# Altium schematic sheet Sheet6.SchDoc
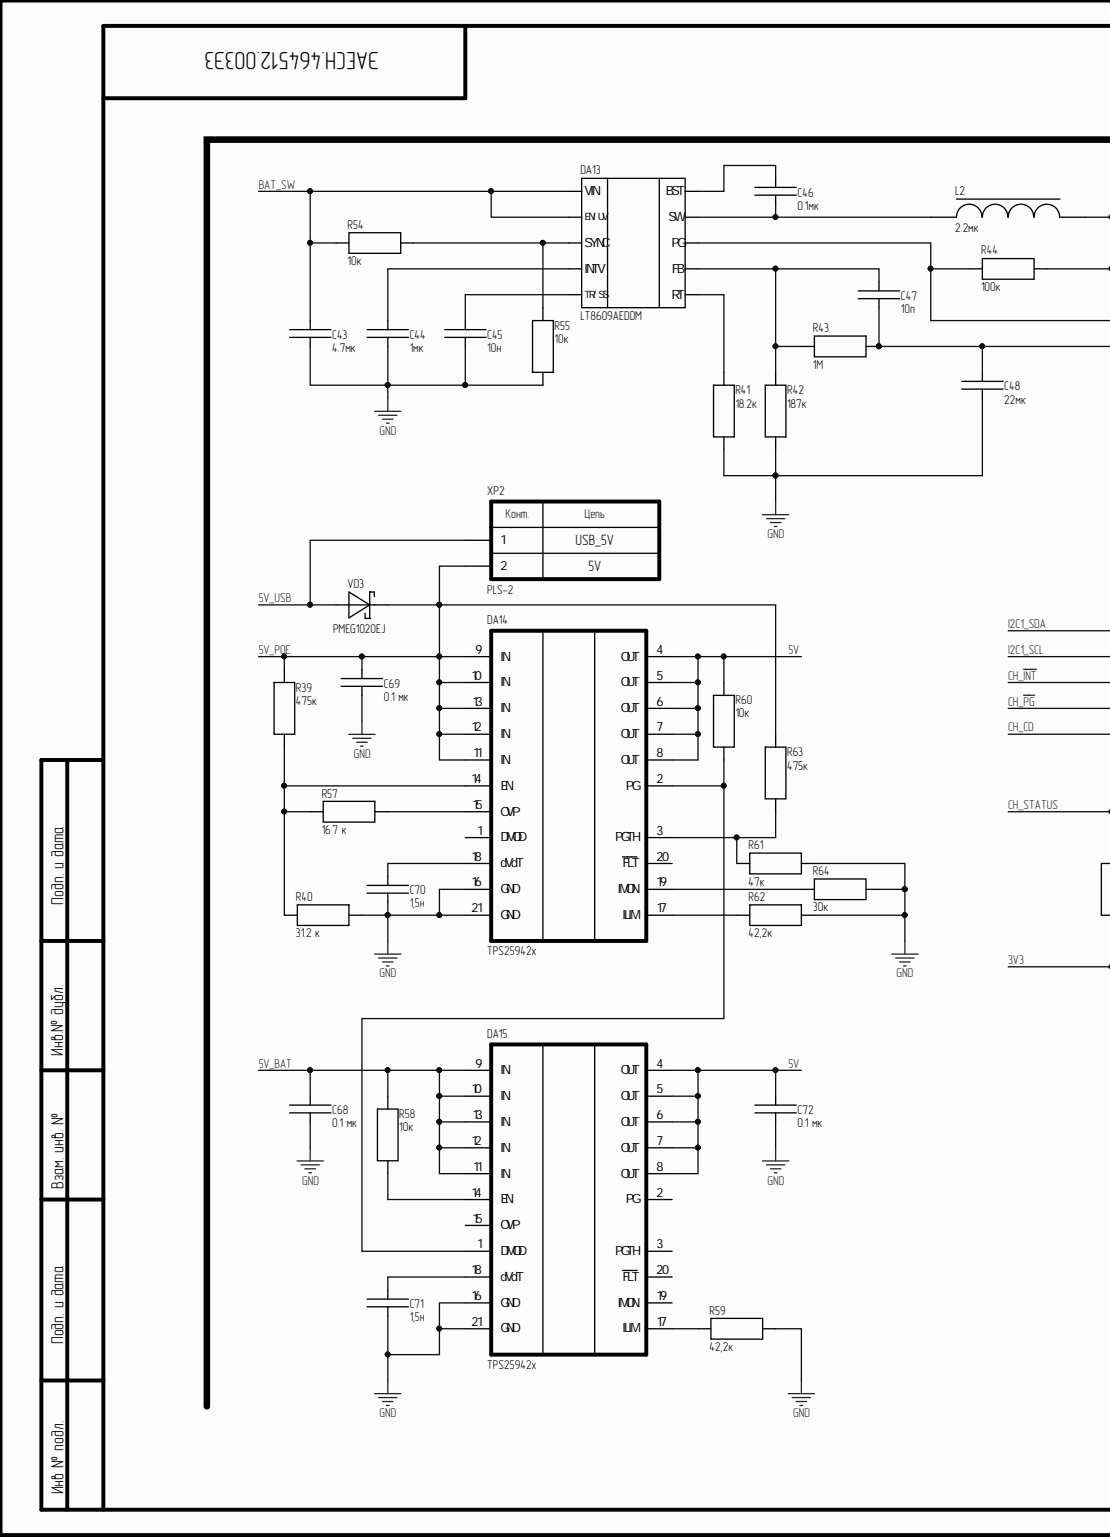
[diagram p0: Sheet6.SchDoc, left]
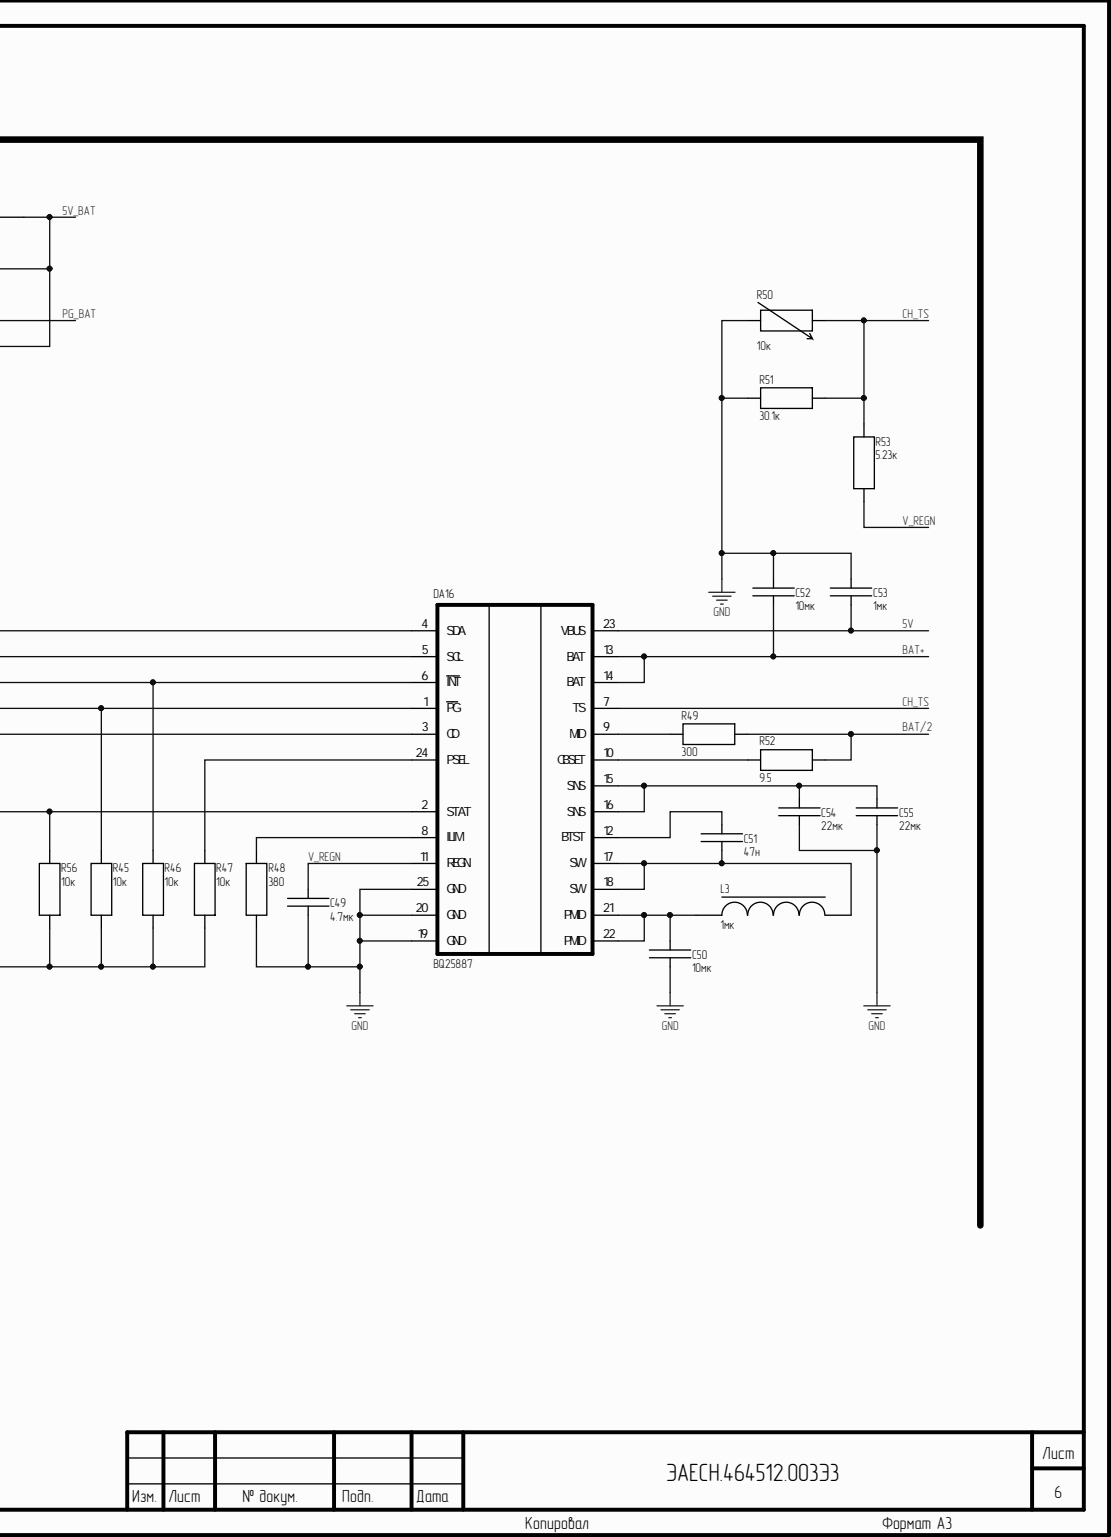
[diagram p1: Sheet6.SchDoc, right]

## Parts
C43  C  value 4.7мк  [С0603]
C44  C  value 1мк  [С0603]
C45  C  value 10н  [С0603]
C46  C  value 0.1мк  [С0603]
C47  C  value 10п  [С0603]
C48  C  value 22мк  [C1206]
C49  C  value 4.7мк  [С0603]
C50  C  value 10мк  [С0603]
C51  C  value 47н  [С0603]
C52  C  value 10мк  [С0603]
C53  C  value 1мк  [С0603]
C54  C  value 22мк  [C1206]
C55  C  value 22мк  [C1206]
C68  C  value 0.1 мк  [С0603]
C69  C  value 0.1 мк  [С0603]
C70  C  value 1,5н  [С0603]
C71  C  value 1,5н  [С0603]
C72  C  value 0.1 мк  [С0603]
DA13  LT8609AEDDM  [SON-10]
DA14  TPS25942x  [WQFN-20]
DA15  TPS25942x  [WQFN-20]
DA16  BQ25887  [VQFN-24]
L2  2.2мк  [IND_6.5x6.5_VISHAY]
L3  1мк  [IND_6.5x6.5_VISHAY]
R39  R  value 475к  [R0603]
R40  R  value 31.2 к  [R0603]
R41  R  value 18.2к  [R0603]
R42  R  value 187к  [R0603]
R43  R  value 1М  [R0603]
R44  R  value 100к  [R0603]
R45  R  value 10к  [R0603]
R46  R  value 10к  [R0603]
R47  R  value 10к  [R0603]
R48  R  value 380  [R0603]
R49  R  value 300  [R0603]
R50  value 10к  [R0603]  (hidden comment: EWTF03-103H3T-N)
R51  R  value 30.1к  [R0603]
R52  R  value 9.5  [R0603]
R53  R  value 5.23к  [R0603]
R54  R  value 10к  [R0603]
R55  R  value 10к  [R0603]
R56  R  value 10к  [R0603]
R57  R  value 16.7 к  [R0603]
R58  R  value 10к  [R0603]
R59  R  value 42,2к  [R0603]
R60  R  value 10к  [R0603]
R61  R  value 47к  [R0603]
R62  R  value 42,2к  [R0603]
R63  R  value 475к  [R0603]
R64  R  value 30к  [R0603]
VD3  PMEG1020EJ  [SOD323F]
XP2  PLS-2  [PLS-2]

## Nets
GND: C43.2 C44.2 C45.2 C48.2 C49.2 C50.2 C52.1 C53.1 C54.2 C55.2 C68.2 C69.2 C70.2 C71.2 C72.2 DA14.16(GND) DA14.21(GND) DA15.16(GND) DA15.21(GND) DA16.19(GND) DA16.20(GND) DA16.25(GND) R40.2 R41.1 R42.2 R48.1 R50.1 R51.1 R55.1 R59.2 R61.1 R62.2 R64.1
3V3: R45.2 R46.2 R47.2 R56.2
5V: C53.2 C72.1 DA14.4(OUT) DA14.5(OUT) DA14.6(OUT) DA14.7(OUT) DA14.8(OUT) DA15.4(OUT) DA15.5(OUT) DA15.6(OUT) DA15.7(OUT) DA15.8(OUT) DA16.23(VBUS) R60.2
5V_BAT: C47.1 C48.1 C68.1 DA15.9(IN) DA15.10(IN) DA15.11(IN) DA15.12(IN) DA15.13(IN) L2.1 R43.2 R44.1 R58.2
5V_POE: C69.1 DA14.9(IN) DA14.10(IN) DA14.11(IN) DA14.12(IN) DA14.13(IN) R39.2 R63.2 VD3.1 XP2.2
5V_USB: VD3.2 XP2.1
BAT+: C52.2 DA16.13(BAT) DA16.14(BAT)
BAT/2: R49.2 R52.2
BAT_SW: C43.1 DA13.9(VIN) DA13.10(EN/_UV) R54.1
CH_CD: DA16.3(CD)
CH_~{INT}: DA16.6(INT) R46.1
CH_~{PG}: DA16.1(PG) R45.1
CH_STATUS: DA16.2(STAT) R56.1
CH_TS: DA16.7(TS) R50.2 R51.2 R53.1
I2C1_SCL: DA16.5(SCL)
I2C1_SDA: DA16.4(SDA)
PG_BAT: DA13.8 R44.2
V_REGN: C49.1 DA16.11(REGN) R53.2
NetC44_1: C44.1 DA13.3(INTV)
NetC45_1: C45.1 DA13.7(TR/_SS)
NetC46_1: C46.1 DA13.1(BST)
NetC46_2: C46.2 DA13.2(SW) L2.2
NetC47_2: C47.2 DA13.6(FB) R42.1 R43.1
NetC50_1: C50.1 DA16.21(PMID) DA16.22(PMID) L3.2
NetC51_1: C51.1 DA16.12(BTST)
NetC51_2: C51.2 DA16.17(SW) DA16.18(SW) L3.1
NetC54_1: C54.1 C55.1 DA16.15(SNS) DA16.16(SNS)
NetC70_1: C70.1 DA14.18(dVdT)
NetC71_1: C71.1 DA15.18(dVdT)
NetDA13_4: DA13.4(RT) R41.2
NetDA13_5: DA13.5(SYNC) R54.2 R55.2
NetDA14_2: DA14.2(PG) DA15.1(DMOD) R60.1
NetDA14_3: DA14.3(PGTH) R61.2 R63.1
NetDA14_14: DA14.14(EN) R39.1 R40.1 R57.1
NetDA14_15: DA14.15(OVP) R57.2
NetDA14_17: DA14.17(ILIM) R62.1
NetDA14_19: DA14.19(IMON) R64.2
NetDA15_14: DA15.14(EN) R58.1
NetDA15_17: DA15.17(ILIM) R59.1
NetDA16_8: DA16.8(ILIM) R48.2
NetDA16_9: DA16.9(MID) R49.1
NetDA16_10: DA16.10(CBSET) R52.1
NetDA16_24: DA16.24(PSEL) R47.1

Not connected: DA14 1(DMOD) 20(FLT); DA15 2(PG) 3(PGTH) 15(OVP) 19(IMON) 20(FLT)

## Library data of the parts
L2 = L: PartNumber: IHLP2525CZER2R2MA1; Component Kind: Standard; Library Name: Schlib1.SchLib
L3 = L: PartNumber: IHLP2525CZER1R0M01; Component Kind: Standard; Library Name: Schlib1.SchLib
R50 = R_term_n: Component Kind: Standard; Library Name: Schlib1.SchLib
VD3 = VD_sh: Component Kind: Standard; Library Name: Schlib1.SchLib
XP2 = 2pin: Component Kind: Standard; Library Name: Schlib1.SchLib
C43-C55 C68-C72 = C: Component Kind: Standard; Library Name: Schlib1.SchLib
DA13 = LT8609AEDDM: Component Kind: Standard; Library Name: Schlib1.SchLib
DA14 DA15 = TPS25942x: Component Kind: Standard; Library Name: Schlib1.SchLib
DA16 = BQ25887: Component Kind: Standard; Library Name: Schlib1.SchLib
R39-R49 R51-R64 = R: Component Kind: Standard; Library Name: Schlib1.SchLib
